# Altium schematic sheet xCore_Audio.SchDoc
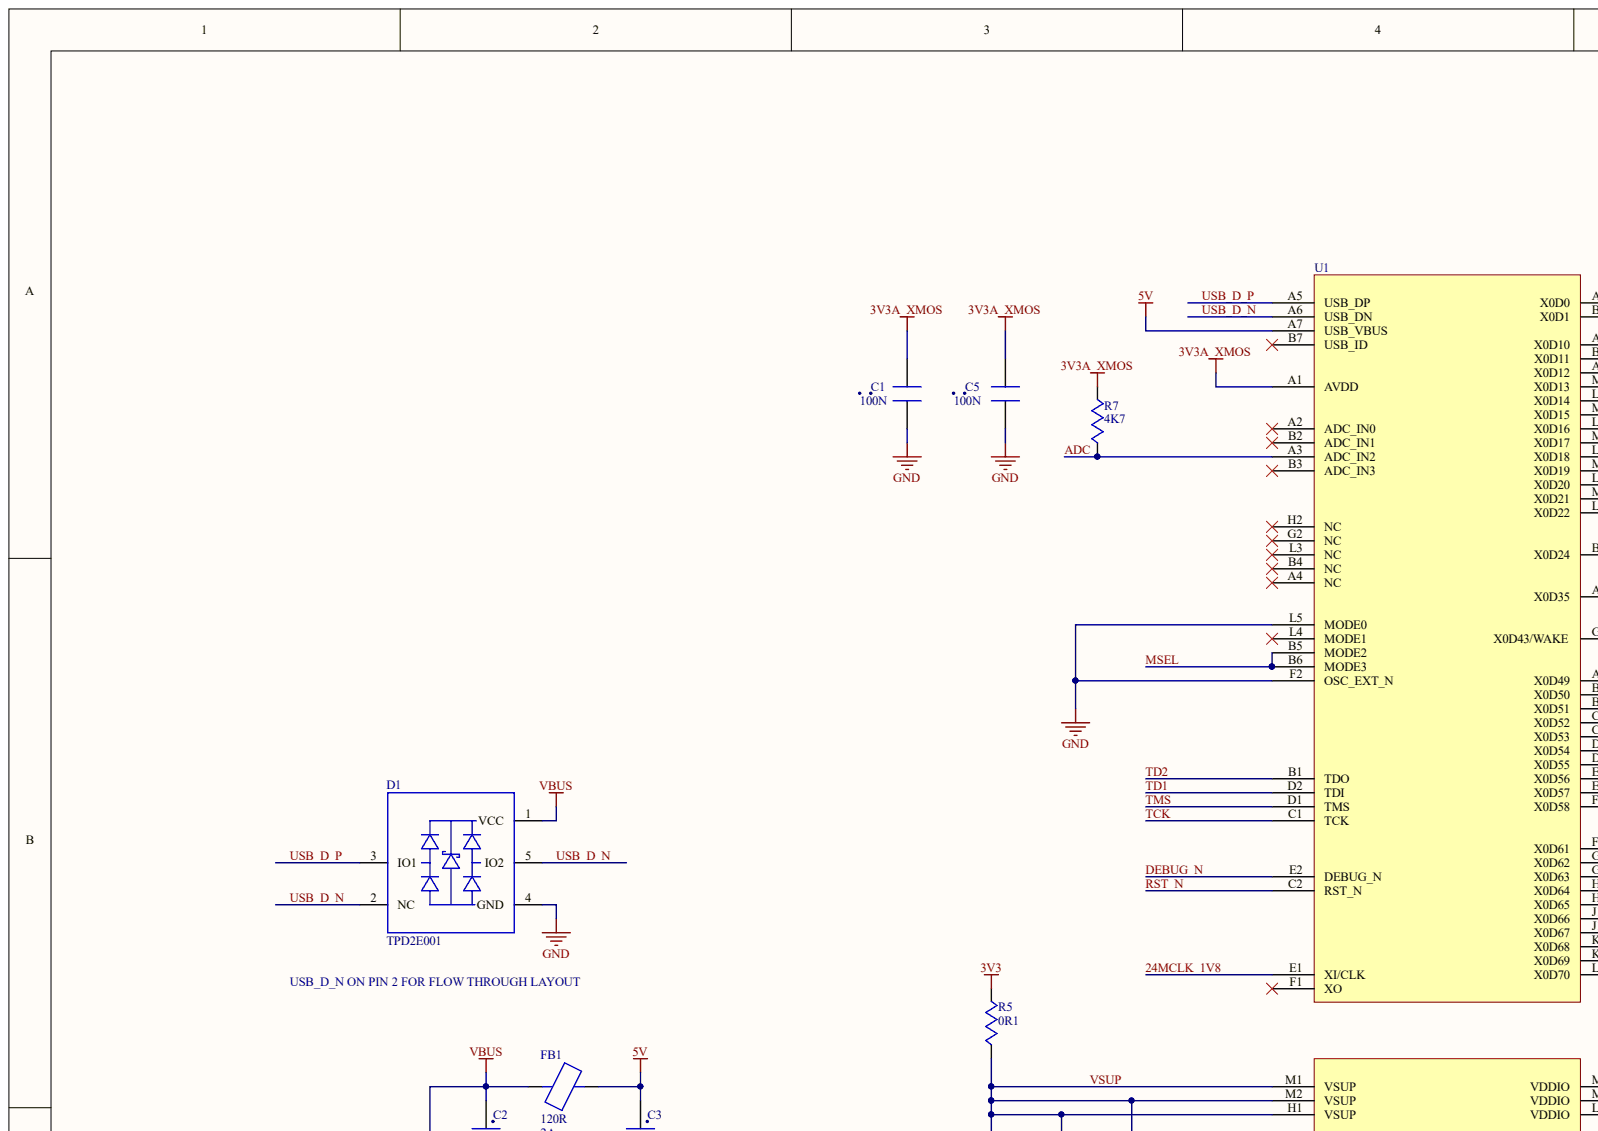
[diagram p0: xCore_Audio.SchDoc, top left]
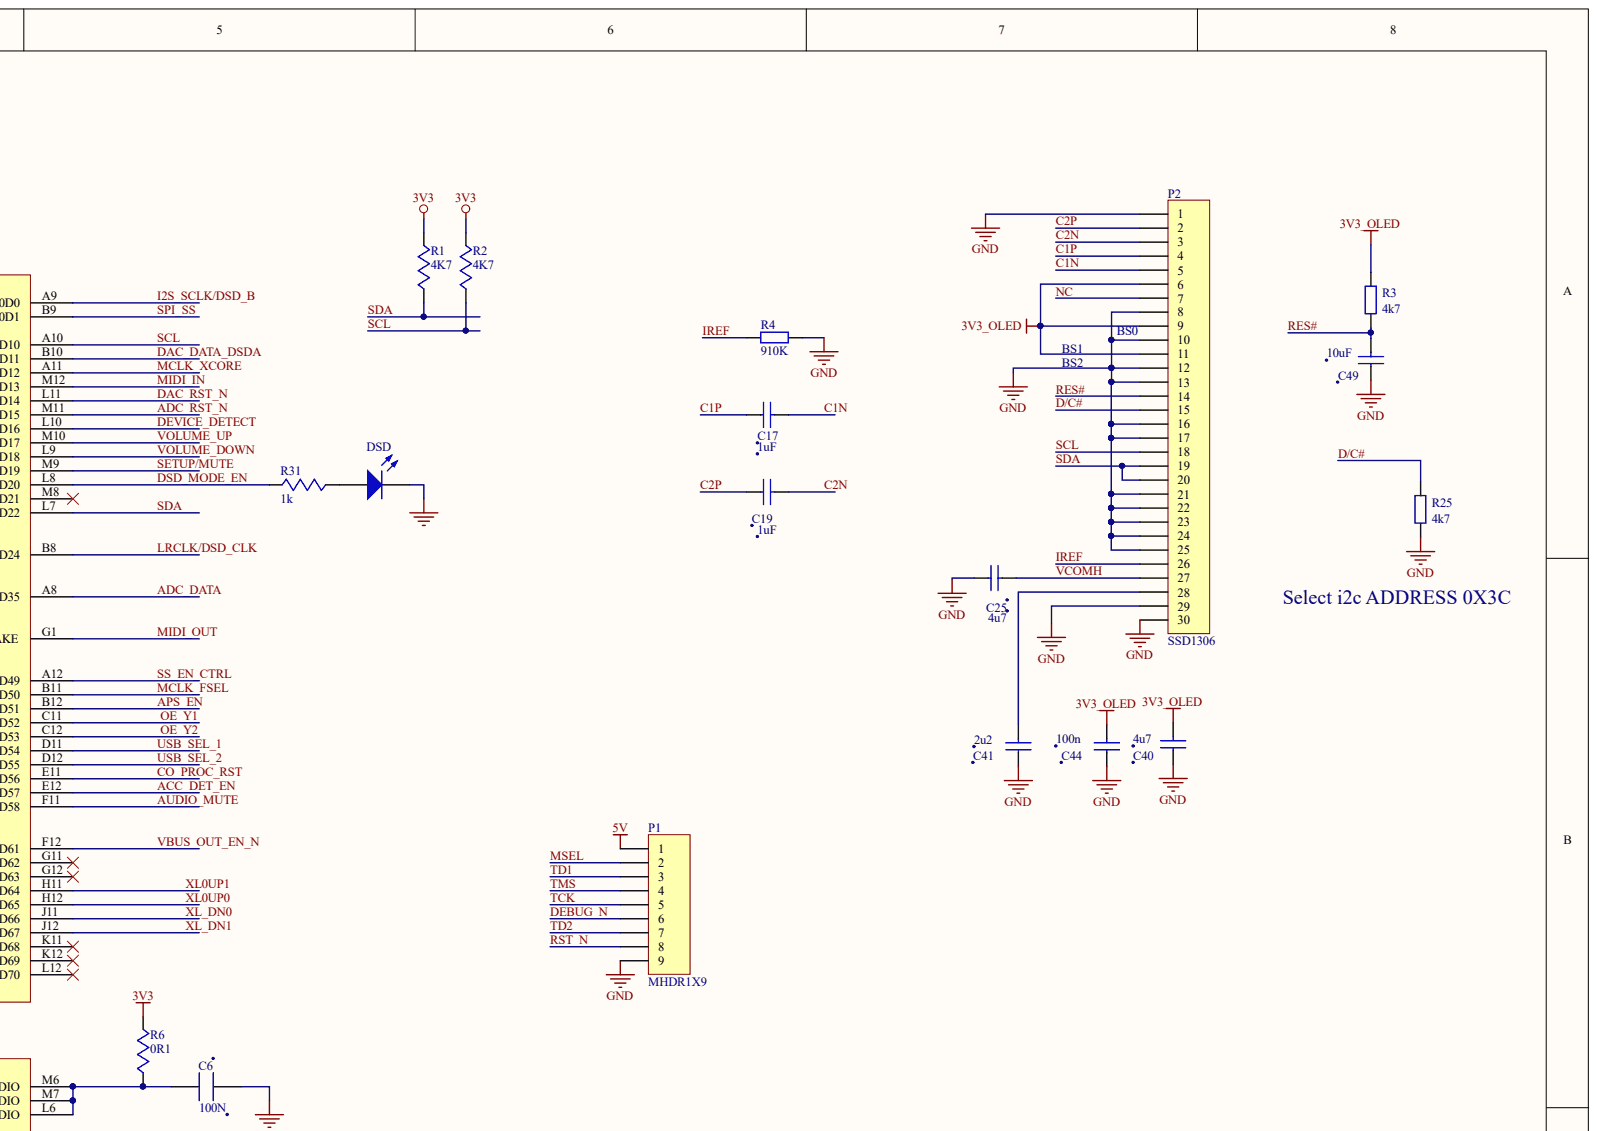
[diagram p1: xCore_Audio.SchDoc, top right]
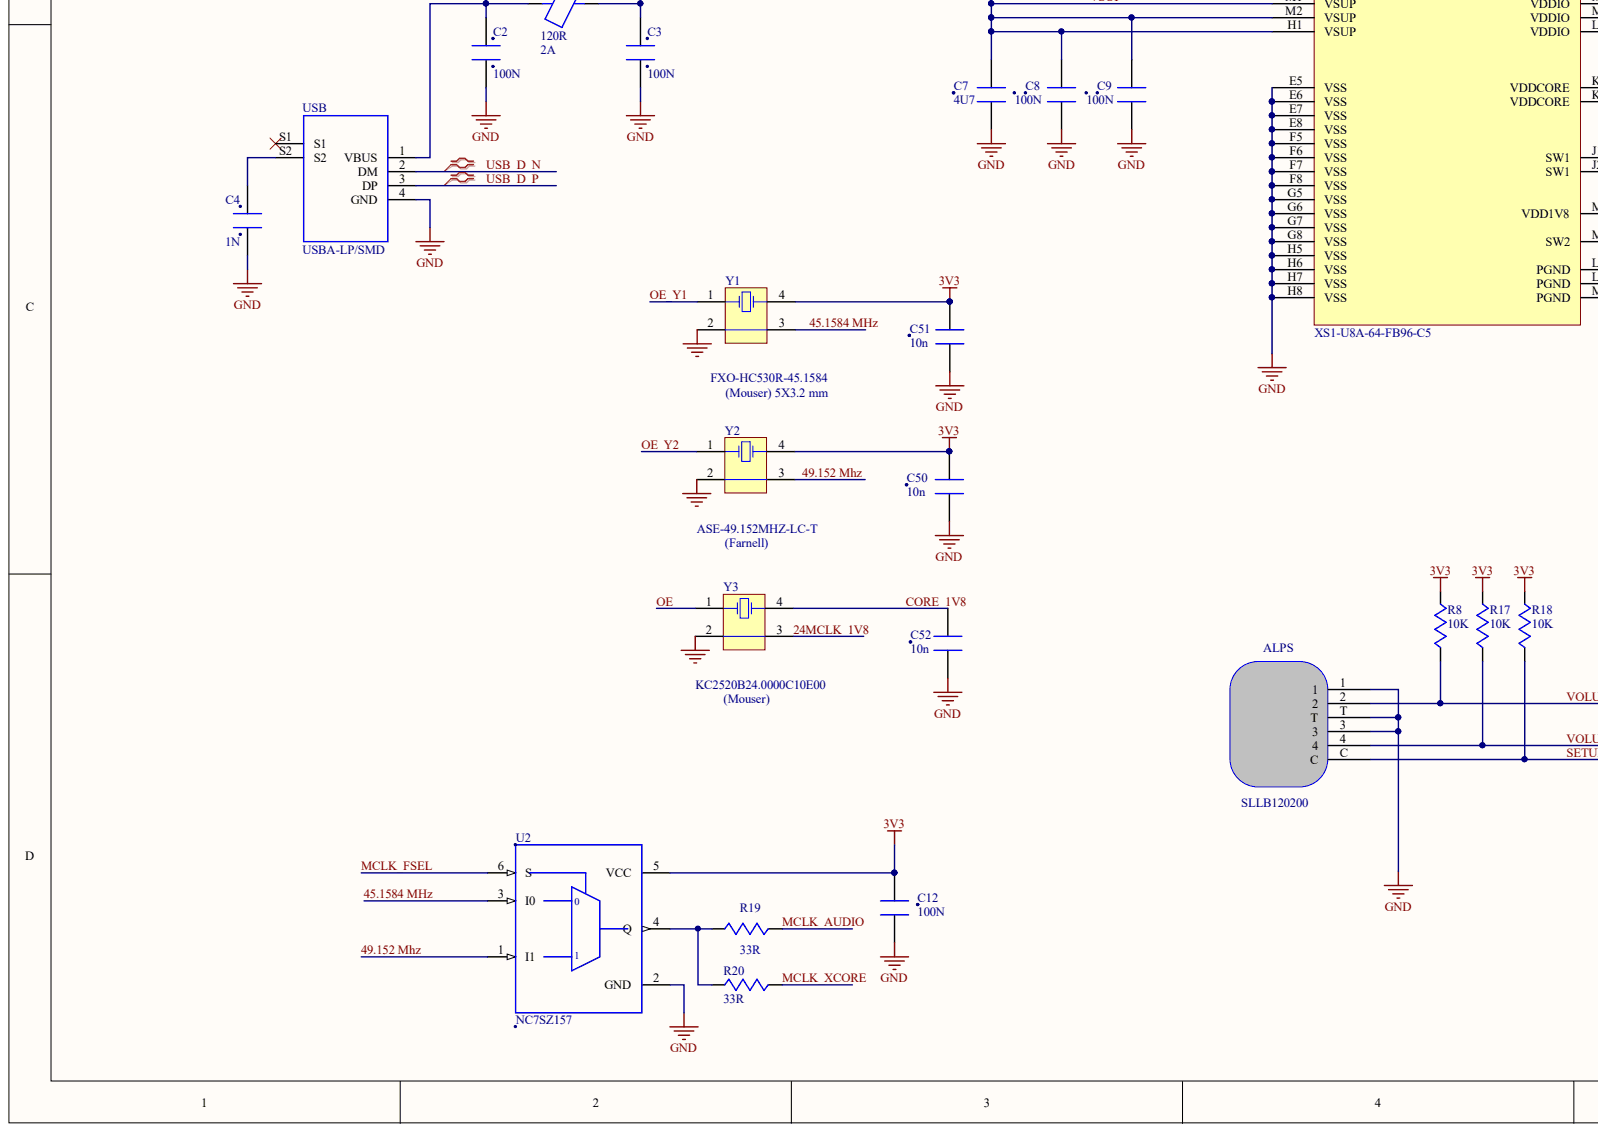
[diagram p2: xCore_Audio.SchDoc, bottom left]
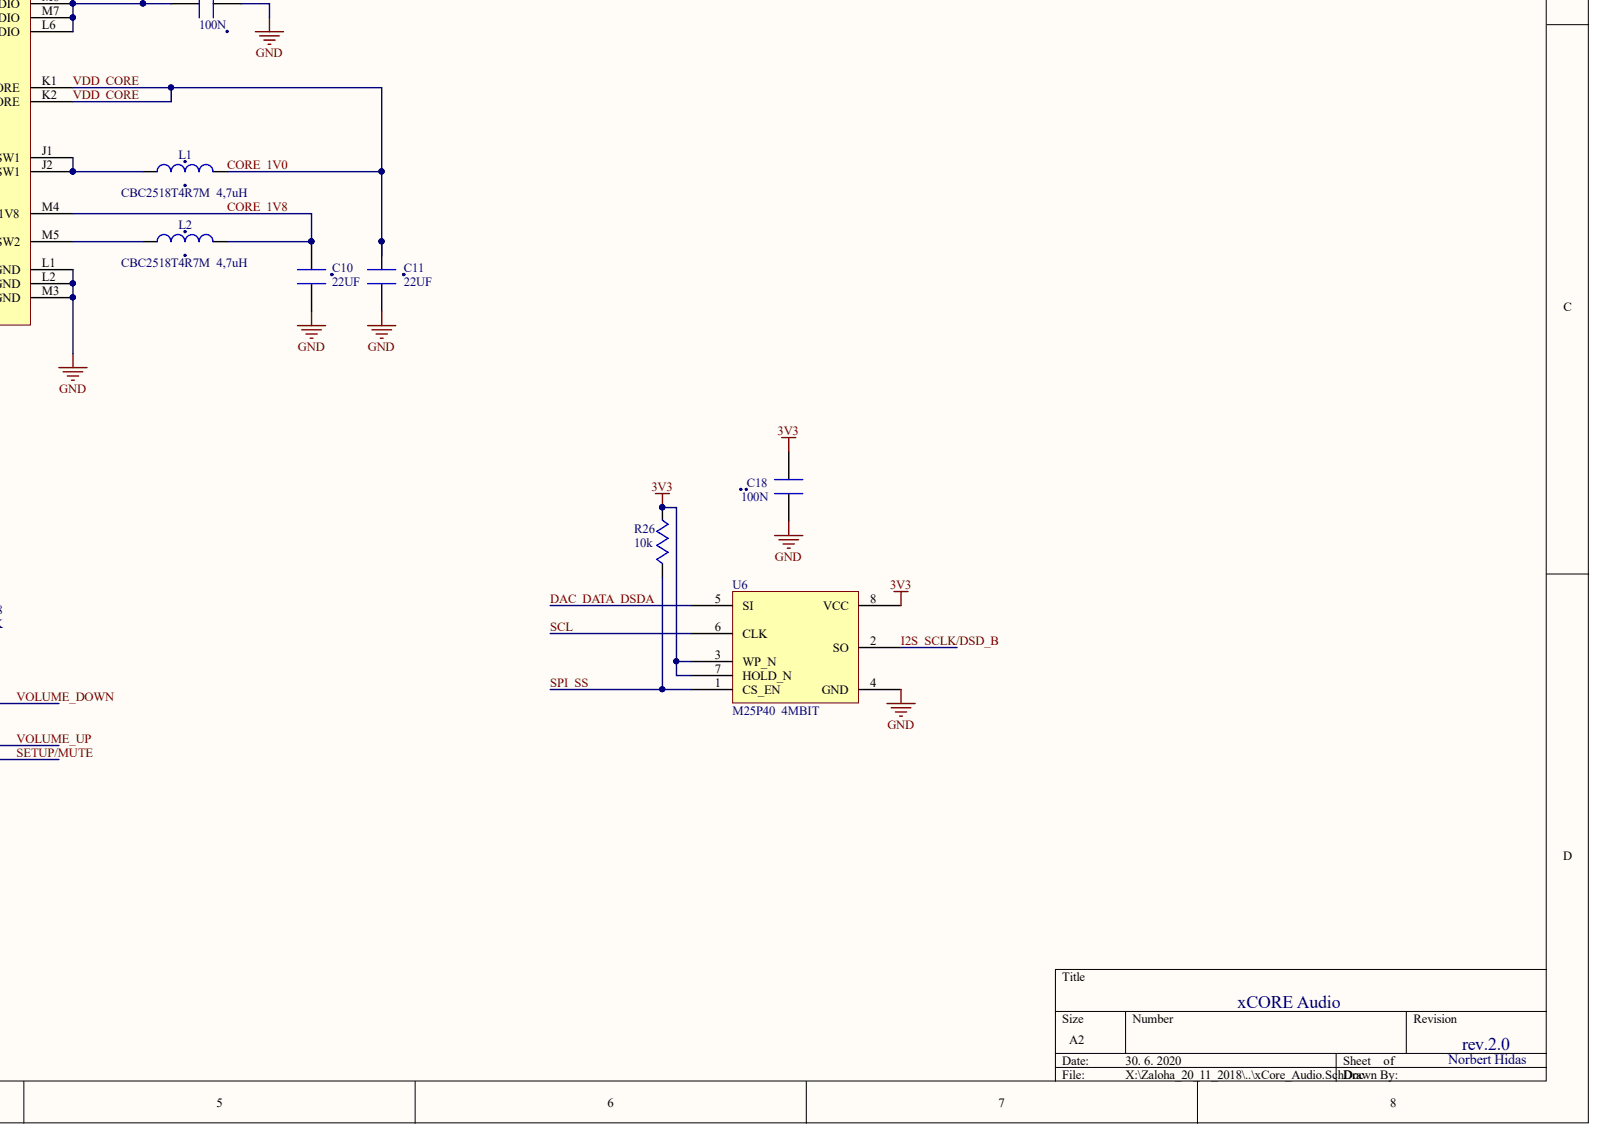
[diagram p3: xCore_Audio.SchDoc, bottom right]

## Parts
ALPS  SLLB120200
C1  100N
C2  100N
C3  100N
C4  1N
C5  100N
C6  100N
C7  4U7
C8  100N
C9  100N
C10  22UF
C11  22UF
C12  100N
C17  1uF
C18  100N
C19  1uF
C25  4u7
C40  4u7
C41  2u2
C44  100n
C49  10uF
C50  10n
C51  10n
C52  10n
D1  TPD2E001
DSD  LED2  (value not drawn)
FB1  Rated Current: 2A  (hidden comment: 120R)
L1  CBC2518T4R7M  4,7uH
L2  CBC2518T4R7M  4,7uH
P1  MHDR1X9
P2  SSD1306
R1  4K7
R2  4K7
R3  4K7
R4  910K
R5  0R1
R6  0R1
R7  4K7
R8  10K
R17  10K
R18  10K
R19  33R
R20  33R
R25  4K7
R26  10k
R31  1k
U1  XS1-U8A-64-FB96-C5
U2  NC7SZ157
U6  M25P40  4MBIT
USB  USBA-LP/SMD
Y1  FXO-HC530R-45.1584
Y2  ASE-49.152MHZ-LC-T
Y3  KC2520B24.0000C10E00

## Nets
3V3: C12.2 C18.1 C50.2 C51.2 R1.2 R2.2 R5.2 R6.2 R8.2 R17.2 R18.2 R26.2 U2.5(VCC) U6.3(WP_N) U6.7(HOLD_N) U6.8(VCC) Y1.4 Y2.4
3V3_OLED: C40.2 C44.2 P2.6 P2.9 P2.11 R3.2
3V3A_XMOS: C1.1 C5.1 R7.2 U1.A1(AVDD)
5V: C3.1 FB1.2 P1.1 U1.A7(USB_VBUS)
GND: ALPS.1 ALPS.3 ALPS.T C1.2 C2.2 C3.2 C4.2 C5.2 C6.2 C7.2 C8.1 C9.1 C10.2 C11.2 C12.1 C18.2 C25.1 C40.1 C41.1 C44.1 C49.1 C50.1 C51.1 C52.1 D1.4(GND) DSD.2 P1.9 P2.1 P2.8 P2.10 P2.12 P2.13 P2.16 P2.17 P2.21 P2.22 P2.23 P2.24 P2.25 P2.29 P2.30 R4.2 R25.1 U1.E5(VSS) U1.E6(VSS) U1.E7(VSS) U1.E8(VSS) U1.F2(OSC_EXT_N) U1.F5(VSS) U1.F6(VSS) U1.F7(VSS) U1.F8(VSS) U1.G5(VSS) U1.G6(VSS) U1.G7(VSS) U1.G8(VSS) U1.H5(VSS) U1.H6(VSS) U1.H7(VSS) U1.H8(VSS) U1.L1(PGND) U1.L2(PGND) U1.L5(MODE0) U1.M3(PGND) U2.2(GND) U6.4(GND) USB.4(GND) Y1.2 Y2.2 Y3.2
VBUS: C2.1 D1.1(VCC) FB1.1 USB.1(VBUS)
24MCLK_1V8: U1.E1(XI/CLK) Y3.3
45.1584 MHZ: U2.3(I0) Y1.3
49.152 MHZ: U2.1(I1) Y2.3
ACC_DET_EN: U1.E12(X0D57)
ADC: R7.1 U1.A3(ADC_IN2)
ADC_DATA: U1.A8(X0D35)
ADC_RST_N: U1.M11(X0D15)
APS_EN: U1.B12(X0D51)
AUDIO_MUTE: U1.F11(X0D58)
C1N: C17.2 P2.5
C1P: C17.1 P2.4
C2N: C19.2 P2.3
C2P: C19.1 P2.2
CO_PROC_RST: U1.E11(X0D56)
CORE_1V0: C11.1 L1.2 U1.K1(VDDCORE) U1.K2(VDDCORE)
CORE_1V8: C10.1 C52.2 L2.2 U1.M4(VDD1V8) Y3.4
D/C#: P2.15 R25.2
DAC_DATA_DSDA: U1.B10(X0D11) U6.5(SI)
DAC_RST_N: U1.L11(X0D14)
DEBUG_N: P1.6 U1.E2(DEBUG_N)
DEVICE_DETECT: U1.L10(X0D16)
DSD_MODE_EN: R31.2 U1.L8(X0D20)
I2S_SCLK/DSD_B: U1.A9(X0D0) U6.2(SO)
IREF: P2.26 R4.1
LRCLK/DSD_CLK: U1.B8(X0D24)
MCLK_AUDIO: R19.1
MCLK_FSEL: U1.B11(X0D50) U2.6(S)
MCLK_XCORE: R20.1 U1.A11(X0D12)
MIDI_IN: U1.M12(X0D13)
MIDI_OUT: U1.G1(X0D43/WAKE)
MSEL: P1.2 U1.B5(MODE2) U1.B6(MODE3)
NC: P2.7
OE: Y3.1
OE_Y1: U1.C11(X0D52) Y1.1
OE_Y2: U1.C12(X0D53) Y2.1
RES#: C49.2 P2.14 R3.1
RST_N: P1.8 U1.C2(RST_N)
SCL: P2.18 R2.1 U1.A10(X0D10) U6.6(CLK)
SDA: P2.19 P2.20 R1.1 U1.L7(X0D22)
SETUP/MUTE: ALPS.C R18.1 U1.M9(X0D19)
SPI_SS: R26.1 U1.B9(X0D1) U6.1(CS_EN)
SS_EN_CTRL: U1.A12(X0D49)
TCK: P1.5 U1.C1(TCK)
TD1: P1.3 U1.D2(TDI)
TD2: P1.7 U1.B1(TDO)
TMS: P1.4 U1.D1(TMS)
USB_D_N: D1.2(NC) D1.5(IO2) U1.A6(USB_DN) USB.2(DM)
USB_D_P: D1.3(IO1) U1.A5(USB_DP) USB.3(DP)
USB_SEL_1: U1.D11(X0D54)
USB_SEL_2: U1.D12(X0D55)
VBUS_OUT_EN_N: U1.F12(X0D61)
VCOMH: C25.2 P2.27
VOLUME_DOWN: ALPS.2 R8.1 U1.L9(X0D18)
VOLUME_UP: ALPS.4 R17.1 U1.M10(X0D17)
VSUP: C7.1 C8.2 C9.2 R5.1 U1.H1(VSUP) U1.M1(VSUP) U1.M2(VSUP)
XL0UP0: U1.H12(X0D65)
XL0UP1: U1.H11(X0D64)
XL_DN0: U1.J11(X0D66)
XL_DN1: U1.J12(X0D67)
NetC4_1: C4.1 USB.S2
NetC6_1: C6.1 R6.1 U1.L6(VDDIO) U1.M6(VDDIO) U1.M7(VDDIO)
NetC41_2: C41.2 P2.28
NetDSD_1: DSD.1 R31.1
NetL1_1: L1.1 U1.J1(SW1) U1.J2(SW1)
NetL2_1: L2.1 U1.M5(SW2)
NetR19_2: R19.2 R20.2 U2.4(Q)

Not connected: U1 A2(ADC_IN0) A4(NC) B2(ADC_IN1) B3(ADC_IN3) B4(NC) B7(USB_ID) F1(XO) G2(NC) G11(X0D62) G12(X0D63) H2(NC) K11(X0D68) K12(X0D69) L3(NC) L4(MODE1) L12(X0D70) M8(X0D21); USB S1

## Library data of the parts
C1-C3 C5 C6 C8 C9 C12 C18 = (no symbol name): Part Number: STD-06-0048; Manufacturer: Yageo; Manufacturer Part Number: CC0402KRX7R7BB104; Dielectric: X7R; Rated Voltage: 16V; Tolerance: 10%; Approx Volume Cost ($): 0.003; VALUE: 100N
C4 = (no symbol name): Part Number: STD-06-0036; Manufacturer: Yageo; Manufacturer Part Number: CC0402KRX7R9BB102; Dielectric: X7R; Rated Voltage: 50V; Tolerance: 10%; Approx Volume Cost ($): 0.002; VALUE: 1N
C7 C10 C11 = (no symbol name): Part Number: STD-06-0090; Manufacturer: Murata; Manufacturer Part Number: GRM21BR61A106KE19L; VALUE: 10U; Dielectric: X5R; Rated Voltage: 10V; Tolerance: 10%; Approx Volume Cost ($): 0.04
C50-C52 = (no symbol name): Part Number: STD-06-0048; Manufacturer: Yageo; Manufacturer Part Number: CC0402KRX7R7BB104; VALUE: 100N; Dielectric: X7R; Rated Voltage: 16V; Tolerance: 10%; Approx Volume Cost ($): 0.003
D1 = (no symbol name): Part Number: GEN-16-0003; Manufacturer: Texas Instruments; Manufacturer Part Number: TPD2E001DRLR; Approx Volume Cost ($): 0.26; VALUE: TPD2E001
FB1 = (no symbol name): Part Number: GEN-04-0004; Manufacturer: Murata; Manufacturer Part Number: BLM18PG121SN1; Max DCR: 0.05R; Approx Volume Cost ($): 0.022; VALUE: 120R
L1 L2 = (no symbol name): Part Number: GEN-05-0004; Manufacturer: Taiyo Yuden; Manufacturer Part Number: BRC2012T2R2MD; VALUE: 2U2; Tolerance: 20%; Max DC Resistance: 0.143R; Rated Current (Saturation): 1.1A; Rated Current (Temp Rise): 1.0A; Approx Volume Cost ($): 0.1
R1 R2 R5-R8 R17-R20 R31 = (no symbol name): Part Number: STD-01-0064; Manufacturer: Yageo; Manufacturer Part Number: RC0402FR-072K2L; VALUE: 2K2; Tolerance: 1%; Max Voltage: 50V; Max Power: 63mW; Temperature Coefficient: ±100ppm/°C; Approx Volume Cost ($): 0.002
R26 = (no symbol name): Part Number: STD-02-0010; Manufacturer: Yageo; Manufacturer Part Number: RC0603JR-074R7L; VALUE: 4R7; Tolerance: 5%; Max Voltage: 50V; Max Power: 100mW; Temperature Coefficient: ±200ppm/°C; Approx Volume Cost ($): 0.002
U2 = (no symbol name): XMOS Part Number: E-13-0009; Manufacturer: Fairchild; Manufacturer Part Number: NC7SZ157P6X; Part Creator: JG; LEVEL: STD; DEVICE: ??; FORWARD_PCB: 1; Approx Volume Cost ($): 0.07; Approved: NO; VALUE: NC7SZ157; Datasheet: http://www.fairchildsemi.com/ds/NC/NC7SZ157.pdf; Max Height (mm): 1.1
USB = (no symbol name): Part Number: GEN-01-0020; Manufacturer: FCI; Manufacturer Part Number: 10118193-0001LF; VALUE: 10118193; Approx Volume Cost ($): 0.19
ALPS C17 C19 C25 C40 C41 C44 C49 DSD P1 P2 R3 R4 R25 U1 U6 Y1-Y3 = (no symbol name)
  DSD: Published: 8-Jun-2000; LatestRevisionDate: 17-Jul-2002; LatestRevisionNote: Re-released for DXP Platform.; PackageVersion: Feb-2002; PackageReference: 3.2X1.6X1.1; PackageDescription: SM LED; 2 Flat Leads
  P1 P2: LatestRevisionDate: 17-Jul-2002; LatestRevisionNote: Re-released for DXP Platform.
  R3 R25: Published: 8-Jun-2000; LatestRevisionDate: 17-Jul-2002; LatestRevisionNote: Re-released for DXP Platform.; PackageReference: AXIAL-0.4; PackageDescription: Axial Device, Thru-Hole; 2 Leads; 0.4 in Pin Spacing; Value: 4k7
  R4: Published: 8-Jun-2000; LatestRevisionDate: 17-Jul-2002; LatestRevisionNote: Re-released for DXP Platform.; PackageReference: AXIAL-0.4; PackageDescription: Axial Device, Thru-Hole; 2 Leads; 0.4 in Pin Spacing; Value: 910K
  Y1-Y3: Published: 17-Jul-2002; DatasheetDocument: 18-Apr-2000; PackageReference: ECX-3M; ComponentLink1Description: Manufacturer Link; ComponentLink1URL: http://www.ecsxtal.com/
  DSD P1 P2 R3 R4 R25 Y1-Y3: Publisher: Altium Limited
